# Altium schematic sheet DDR.SchDoc(DDR)
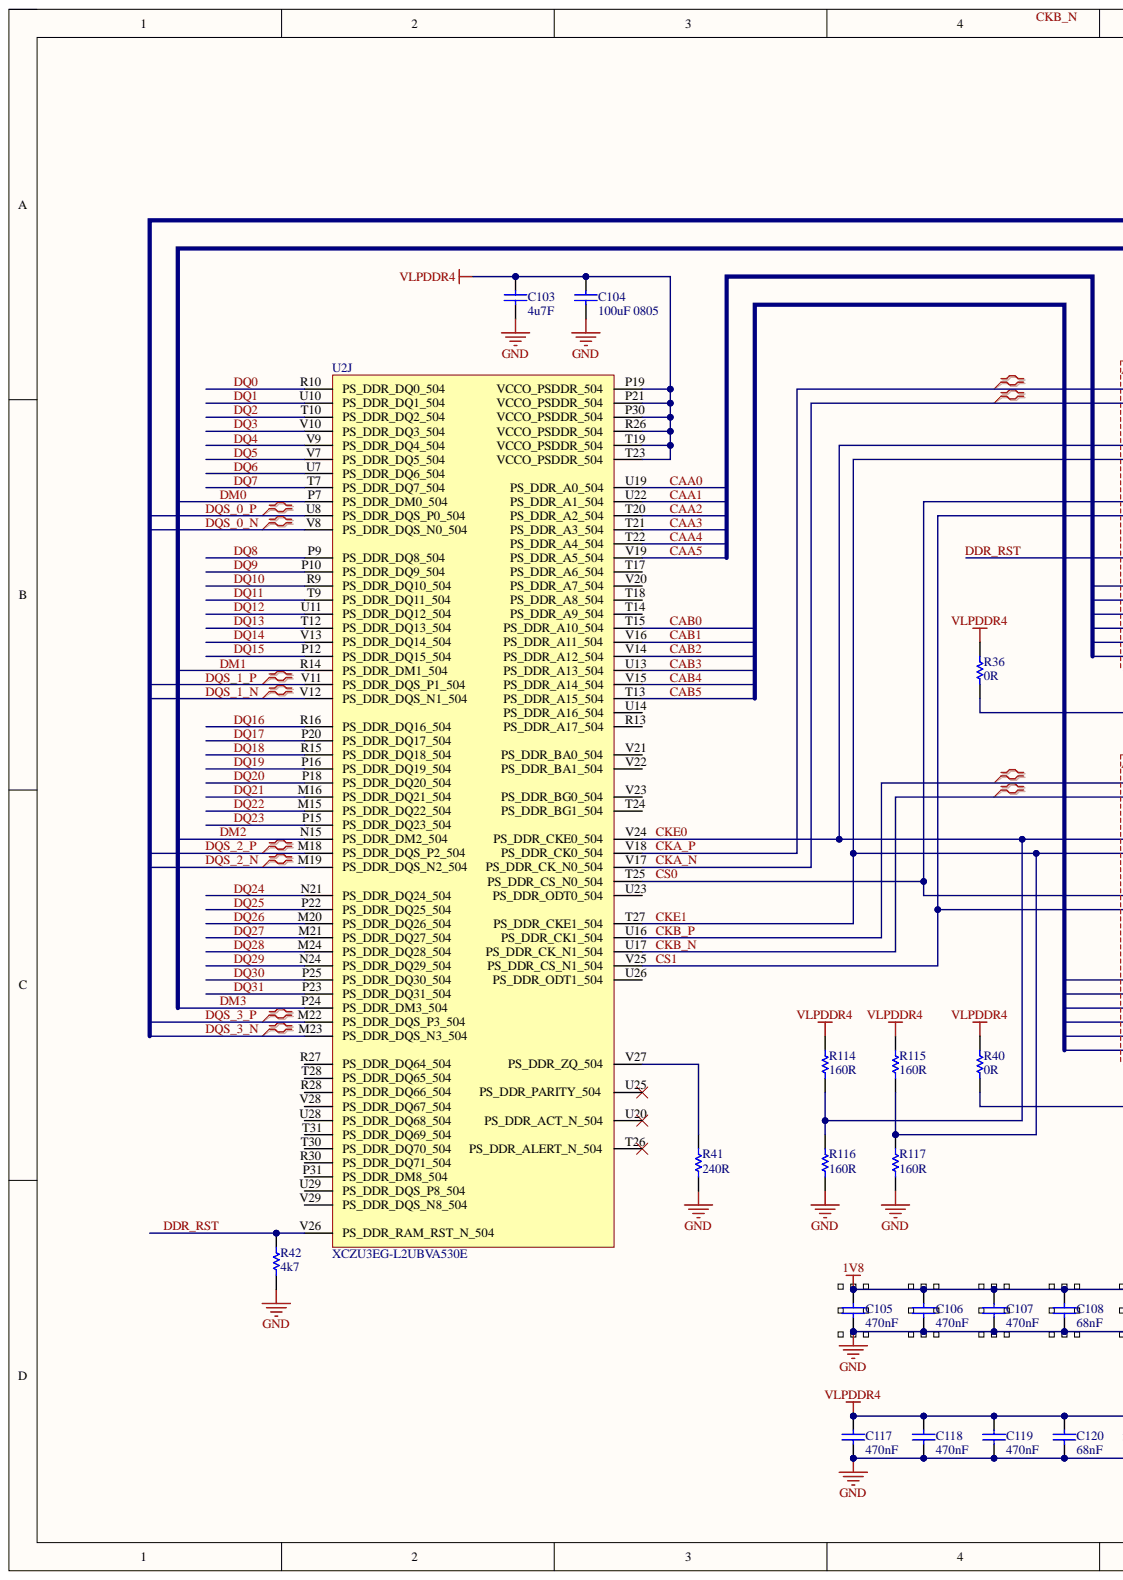
[diagram p0: DDR.SchDoc, left]
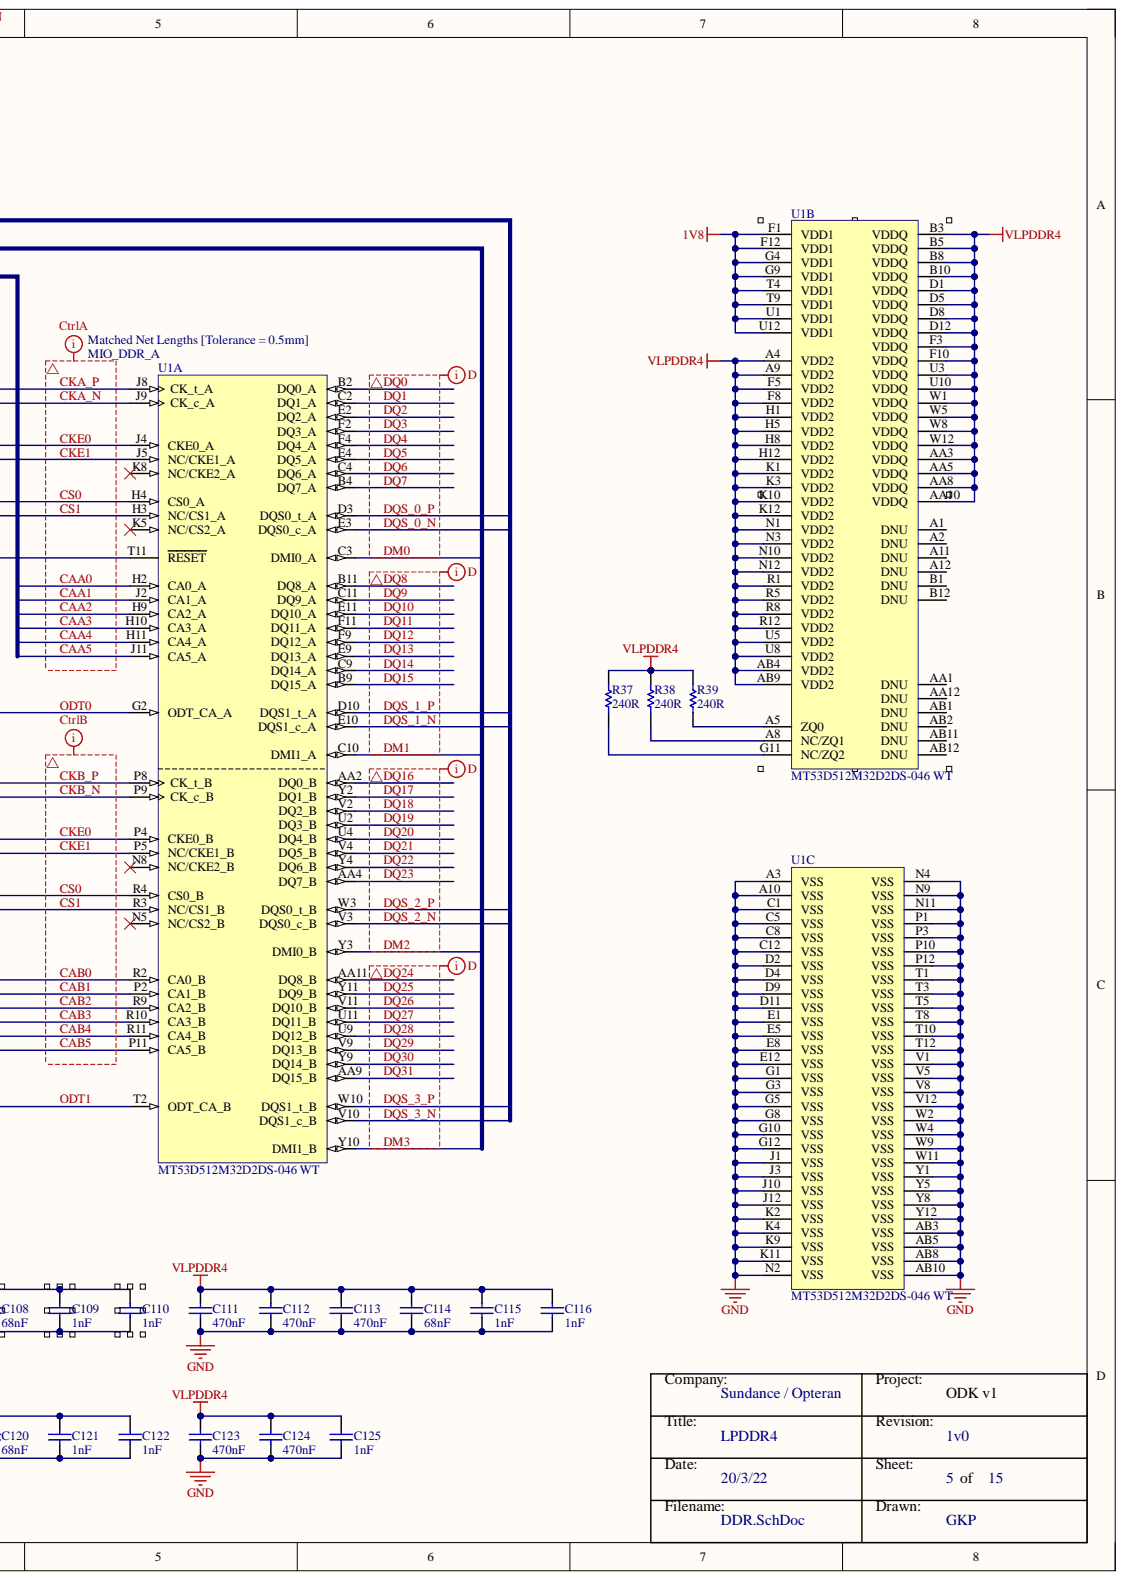
[diagram p1: DDR.SchDoc, right]

## Parts
C103  4u7F  [0201panERJ]
C104  100uF 0805  [CAP0805]
C105  470nF  [0201panERJ]
C106  470nF  [0201panERJ]
C107  470nF  [0201panERJ]
C108  68nF  [0201panERJ]
C109  1nF  [0201panERJ]
C110  1nF  [0201panERJ]
C111  470nF  [0201panERJ]
C112  470nF  [0201panERJ]
C113  470nF  [0201panERJ]
C114  68nF  [0201panERJ]
C115  1nF  [0201panERJ]
C116  1nF  [0201panERJ]
C117  470nF  [0201panERJ]
C118  470nF  [0201panERJ]
C119  470nF  [0201panERJ]
C120  68nF  [0201panERJ]
C121  1nF  [0201panERJ]
C122  1nF  [0201panERJ]
C123  470nF  [0201panERJ]
C124  470nF  [0201panERJ]
C125  1nF  [0201panERJ]
R36  0R  [RES0201]
R37  240R  [RES0201]
R38  240R  [RES0201]
R39  240R  [RES0201]
R40  0R  [RES0201]
R41  240R  [RES0201]
R42  4k7  [RES0201]
R114  160R  [RES0201]
R115  160R  [RES0201]
R116  160R  [RES0201]
R117  160R  [RES0201]
U1  MT53D512M32D2DS-046 WT  [ISSI 200L TF-BGA 1]  (parts A,B,C)
U2  XCZU3EG-L2UBVA530E  [UBVA530]  (part J)

## Nets
1V8: C105.1 C106.1 C107.1 C108.1 C109.1 C110.1 U1.F1(VDD1) U1.F12(VDD1) U1.G4(VDD1) U1.G9(VDD1) U1.T4(VDD1) U1.T9(VDD1) U1.U1(VDD1) U1.U12(VDD1)
GND: C103.1 C104.1 C105.2 C106.2 C107.2 C108.2 C109.2 C110.2 C111.2 C112.2 C113.2 C114.2 C115.2 C116.2 C117.2 C118.2 C119.2 C120.2 C121.2 C122.2 C123.2 C124.2 C125.2 R41.1 R42.1 R116.1 R117.1 U1.A3(VSS) U1.A10(VSS) U1.AB3(VSS) U1.AB5(VSS) U1.AB8(VSS) U1.AB10(VSS) U1.C1(VSS) U1.C5(VSS) U1.C8(VSS) U1.C12(VSS) U1.D2(VSS) U1.D4(VSS) U1.D9(VSS) U1.D11(VSS) U1.E1(VSS) U1.E5(VSS) U1.E8(VSS) U1.E12(VSS) U1.G1(VSS) U1.G3(VSS) U1.G5(VSS) U1.G8(VSS) U1.G10(VSS) U1.G12(VSS) U1.J1(VSS) U1.J3(VSS) U1.J10(VSS) U1.J12(VSS) U1.K2(VSS) U1.K4(VSS) U1.K9(VSS) U1.K11(VSS) U1.N2(VSS) U1.N4(VSS) U1.N9(VSS) U1.N11(VSS) U1.P1(VSS) U1.P3(VSS) U1.P10(VSS) U1.P12(VSS) U1.T1(VSS) U1.T3(VSS) U1.T5(VSS) U1.T8(VSS) U1.T10(VSS) U1.T12(VSS) U1.V1(VSS) U1.V5(VSS) U1.V8(VSS) U1.V12(VSS) U1.W2(VSS) U1.W4(VSS) U1.W9(VSS) U1.W11(VSS) U1.Y1(VSS) U1.Y5(VSS) U1.Y8(VSS) U1.Y12(VSS)
VLPDDR4: C103.2 C104.2 C111.1 C112.1 C113.1 C114.1 C115.1 C116.1 C117.1 C118.1 C119.1 C120.1 C121.1 C122.1 C123.1 C124.1 C125.1 R36.2 R37.2 R38.2 R39.2 R40.2 R114.2 R115.2 U1.A4(VDD2) U1.A9(VDD2) U1.AA3(VDDQ) U1.AA5(VDDQ) U1.AA8(VDDQ) U1.AA10(VDDQ) U1.AB4(VDD2) U1.AB9(VDD2) U1.B3(VDDQ) U1.B5(VDDQ) U1.B8(VDDQ) U1.B10(VDDQ) U1.D1(VDDQ) U1.D5(VDDQ) U1.D8(VDDQ) U1.D12(VDDQ) U1.F3(VDDQ) U1.F5(VDD2) U1.F8(VDD2) U1.F10(VDDQ) U1.H1(VDD2) U1.H5(VDD2) U1.H8(VDD2) U1.H12(VDD2) U1.K1(VDD2) U1.K3(VDD2) U1.K10(VDD2) U1.K12(VDD2) U1.N1(VDD2) U1.N3(VDD2) U1.N10(VDD2) U1.N12(VDD2) U1.R1(VDD2) U1.R5(VDD2) U1.R8(VDD2) U1.R12(VDD2) U1.U3(VDDQ) U1.U5(VDD2) U1.U8(VDD2) U1.U10(VDDQ) U1.W1(VDDQ) U1.W5(VDDQ) U1.W8(VDDQ) U1.W12(VDDQ) U2.P19(VCCO_PSDDR_504) U2.P21(VCCO_PSDDR_504) U2.P30(VCCO_PSDDR_504) U2.R26(VCCO_PSDDR_504) U2.T19(VCCO_PSDDR_504) U2.T23(VCCO_PSDDR_504)
CAA0: U1.H2(CA0_A) U2.U19(PS_DDR_A0_504)
CAA1: U1.J2(CA1_A) U2.U22(PS_DDR_A1_504)
CAA2: U1.H9(CA2_A) U2.T20(PS_DDR_A2_504)
CAA3: U1.H10(CA3_A) U2.T21(PS_DDR_A3_504)
CAA4: U1.H11(CA4_A) U2.T22(PS_DDR_A4_504)
CAA5: U1.J11(CA5_A) U2.V19(PS_DDR_A5_504)
CAB0: U1.R2(CA0_B) U2.T15(PS_DDR_A10_504)
CAB1: U1.P2(CA1_B) U2.V16(PS_DDR_A11_504)
CAB2: U1.R9(CA2_B) U2.V14(PS_DDR_A12_504)
CAB3: U1.R10(CA3_B) U2.U13(PS_DDR_A13_504)
CAB4: U1.R11(CA4_B) U2.V15(PS_DDR_A14_504)
CAB5: U1.P11(CA5_B) U2.T13(PS_DDR_A15_504)
CKA_N: U1.J9(CK_c_A) U2.V17(PS_DDR_CK_N0_504)
CKA_P: U1.J8(CK_t_A) U2.V18(PS_DDR_CK0_504)
CKB_N: U1.P9(CK_c_B) U2.U17(PS_DDR_CK_N1_504)
CKB_P: U1.P8(CK_t_B) U2.U16(PS_DDR_CK1_504)
CKE0: R114.1 R116.2 U1.J4(CKE0_A) U1.P4(CKE0_B) U2.V24(PS_DDR_CKE0_504)
CKE1: R115.1 R117.2 U1.J5(NC/CKE1_A) U1.P5(NC/CKE1_B) U2.T27(PS_DDR_CKE1_504)
CS0: U1.H4(CS0_A) U1.R4(CS0_B) U2.T25(PS_DDR_CS_N0_504)
CS1: U1.H3(NC/CS1_A) U1.R3(NC/CS1_B) U2.V25(PS_DDR_CS_N1_504)
DDR_RST: R42.2 U1.T11(RESET) U2.V26(PS_DDR_RAM_RST_N_504)
DM0: U1.C3(DMI0_A) U2.P7(PS_DDR_DM0_504)
DM1: U1.C10(DMI1_A) U2.R14(PS_DDR_DM1_504)
DM2: U1.Y3(DMI0_B) U2.N15(PS_DDR_DM2_504)
DM3: U1.Y10(DMI1_B) U2.P24(PS_DDR_DM3_504)
DQ0: U1.B2(DQ0_A) U2.R10(PS_DDR_DQ0_504)
DQ1: U1.C2(DQ1_A) U2.U10(PS_DDR_DQ1_504)
DQ2: U1.E2(DQ2_A) U2.T10(PS_DDR_DQ2_504)
DQ3: U1.F2(DQ3_A) U2.V10(PS_DDR_DQ3_504)
DQ4: U1.F4(DQ4_A) U2.V9(PS_DDR_DQ4_504)
DQ5: U1.E4(DQ5_A) U2.V7(PS_DDR_DQ5_504)
DQ6: U1.C4(DQ6_A) U2.U7(PS_DDR_DQ6_504)
DQ7: U1.B4(DQ7_A) U2.T7(PS_DDR_DQ7_504)
DQ8: U1.B11(DQ8_A) U2.P9(PS_DDR_DQ8_504)
DQ9: U1.C11(DQ9_A) U2.P10(PS_DDR_DQ9_504)
DQ10: U1.E11(DQ10_A) U2.R9(PS_DDR_DQ10_504)
DQ11: U1.F11(DQ11_A) U2.T9(PS_DDR_DQ11_504)
DQ12: U1.F9(DQ12_A) U2.U11(PS_DDR_DQ12_504)
DQ13: U1.E9(DQ13_A) U2.T12(PS_DDR_DQ13_504)
DQ14: U1.C9(DQ14_A) U2.V13(PS_DDR_DQ14_504)
DQ15: U1.B9(DQ15_A) U2.P12(PS_DDR_DQ15_504)
DQ16: U1.AA2(DQ0_B) U2.R16(PS_DDR_DQ16_504)
DQ17: U1.Y2(DQ1_B) U2.P20(PS_DDR_DQ17_504)
DQ18: U1.V2(DQ2_B) U2.R15(PS_DDR_DQ18_504)
DQ19: U1.U2(DQ3_B) U2.P16(PS_DDR_DQ19_504)
DQ20: U1.U4(DQ4_B) U2.P18(PS_DDR_DQ20_504)
DQ21: U1.V4(DQ5_B) U2.M16(PS_DDR_DQ21_504)
DQ22: U1.Y4(DQ6_B) U2.M15(PS_DDR_DQ22_504)
DQ23: U1.AA4(DQ7_B) U2.P15(PS_DDR_DQ23_504)
DQ24: U1.AA11(DQ8_B) U2.N21(PS_DDR_DQ24_504)
DQ25: U1.Y11(DQ9_B) U2.P22(PS_DDR_DQ25_504)
DQ26: U1.V11(DQ10_B) U2.M20(PS_DDR_DQ26_504)
DQ27: U1.U11(DQ11_B) U2.M21(PS_DDR_DQ27_504)
DQ28: U1.U9(DQ12_B) U2.M24(PS_DDR_DQ28_504)
DQ29: U1.V9(DQ13_B) U2.N24(PS_DDR_DQ29_504)
DQ30: U1.Y9(DQ14_B) U2.P25(PS_DDR_DQ30_504)
DQ31: U1.AA9(DQ15_B) U2.P23(PS_DDR_DQ31_504)
DQS_0_N: U1.E3(DQS0_c_A) U2.V8(PS_DDR_DQS_N0_504)
DQS_0_P: U1.D3(DQS0_t_A) U2.U8(PS_DDR_DQS_P0_504)
DQS_1_N: U1.E10(DQS1_c_A) U2.V12(PS_DDR_DQS_N1_504)
DQS_1_P: U1.D10(DQS1_t_A) U2.V11(PS_DDR_DQS_P1_504)
DQS_2_N: U1.V3(DQS0_c_B) U2.M19(PS_DDR_DQS_N2_504)
DQS_2_P: U1.W3(DQS0_t_B) U2.M18(PS_DDR_DQS_P2_504)
DQS_3_N: U1.V10(DQS1_c_B) U2.M23(PS_DDR_DQS_N3_504)
DQS_3_P: U1.W10(DQS1_t_B) U2.M22(PS_DDR_DQS_P3_504)
ODT0: R36.1 U1.G2(ODT_CA_A)
ODT1: R40.1 U1.T2(ODT_CA_B)
NetR37_1: R37.1 U1.G11(NC/ZQ2)
NetR38_1: R38.1 U1.A8(NC/ZQ1)
NetR39_1: R39.1 U1.A5(ZQ0)
NetR41_2: R41.2 U2.V27(PS_DDR_ZQ_504)

Not connected: U1 A1(DNU) A2(DNU) A11(DNU) A12(DNU) AA1(DNU) AA12(DNU) AB1(DNU) AB2(DNU) AB11(DNU) AB12(DNU) B1(DNU) B12(DNU) K5(NC/CS2_A) K8(NC/CKE2_A) N5(NC/CS2_B) N8(NC/CKE2_B); U2 P31(PS_DDR_DM8_504) R13(PS_DDR_A17_504) R27(PS_DDR_DQ64_504) R28(PS_DDR_DQ66_504) R30(PS_DDR_DQ71_504) T14(PS_DDR_A9_504) T17(PS_DDR_A6_504) T18(PS_DDR_A8_504) T24(PS_DDR_BG1_504) T26(PS_DDR_ALERT_N_504) T28(PS_DDR_DQ65_504) T30(PS_DDR_DQ70_504) T31(PS_DDR_DQ69_504) U14(PS_DDR_A16_504) U20(PS_DDR_ACT_N_504) U23(PS_DDR_ODT0_504) U25(PS_DDR_PARITY_504) U26(PS_DDR_ODT1_504) U28(PS_DDR_DQ68_504) U29(PS_DDR_DQS_P8_504) V20(PS_DDR_A7_504) V21(PS_DDR_BA0_504) V22(PS_DDR_BA1_504) V23(PS_DDR_BG0_504) V28(PS_DDR_DQ67_504) V29(PS_DDR_DQS_N8_504)

## Library data of the parts
C103 = Cap0201: 4u7F 0201 6V3 X5R; Case-EIA: 0402; Component Kind: Standard; Library Name: Schematic Components.SchLib; Max Thickness: 0.6 mm; Technology: SMT
C104 = Cap0805: 100uF 4V 0805 X5R; Case-EIA: 0603; Component Kind: Standard; Library Name: Passive LCR.SchLib; Max Thickness: 0.6 mm; Technology: SMT
C105-C107 C111-C113 C117-C119 C123 C124 = Cap0201: 470nF 0201 6V3 X5R; Case-EIA: 0402; Component Kind: Standard; Library Name: Schematic Components.SchLib; Max Thickness: 0.6 mm; Technology: SMT
C108 C114 C120 = Cap0201: 68nF 0201 6V3 X5R; Case-EIA: 0402; Component Kind: Standard; Library Name: Schematic Components.SchLib; Max Thickness: 0.6 mm; Technology: SMT
C109 C110 C115 C116 C121 C122 C125 = Cap0201: 1nF 0201 6V3 X5R; Case-EIA: 0402; Component Kind: Standard; Library Name: Schematic Components.SchLib; Max Thickness: 0.6 mm; Technology: SMT
R36 R40 = Res0201: 0R 0201 1/16W 1%; Value: ?k; Component Kind: Standard; Library Name: Schematic Components.SchLib
R37-R39 R41 = Res0201: 240R 0201 1/16W 1%; Value: ?k; Component Kind: Standard; Library Name: Schematic Components.SchLib
R42 = Res0201: 4k7 0201 1/16W 1%; Value: ?k; Component Kind: Standard; Library Name: Schematic Components.SchLib
R114-R117 = Res0201: 160R 0201 1/16W 1%; Value: ?k; Component Kind: Standard; Library Name: Schematic Components.SchLib
U1 = IS43LQ32256A-062BLI: SDRAM - Mobile LPDDR4 Memory IC 8Gb (256M x 32) Parallel 1.6 GHz 200-TFBGA (10x14.5); Manufacturer 1: Micron; Component Kind: Standard; Library Name: ISSI.SchLib; Manufacturer Part Number 1: MT53D512M32D2DS-046 WT
U2 = XCZU3EG-L2UBVA530E: SoC 32-bit/64-bit ARM Cortex-A53 RISC 1.5GHz Quad Core 530-Pin BGA; Manufacturer 1: Xilinx; Component Kind: Standard; Library Name: Xilinx1.SchLib; Manufacturer Part Number 1: XCZU3EG-L2UBVA530E; Supplier 1: Avnet; Supplier Part Number 1: XCZU3EG-L2UBVA530E
